# Altium schematic sheet TailLightPrototypeRev3LEDs.SchDoc(TailLightPrototypeRev3LEDs)
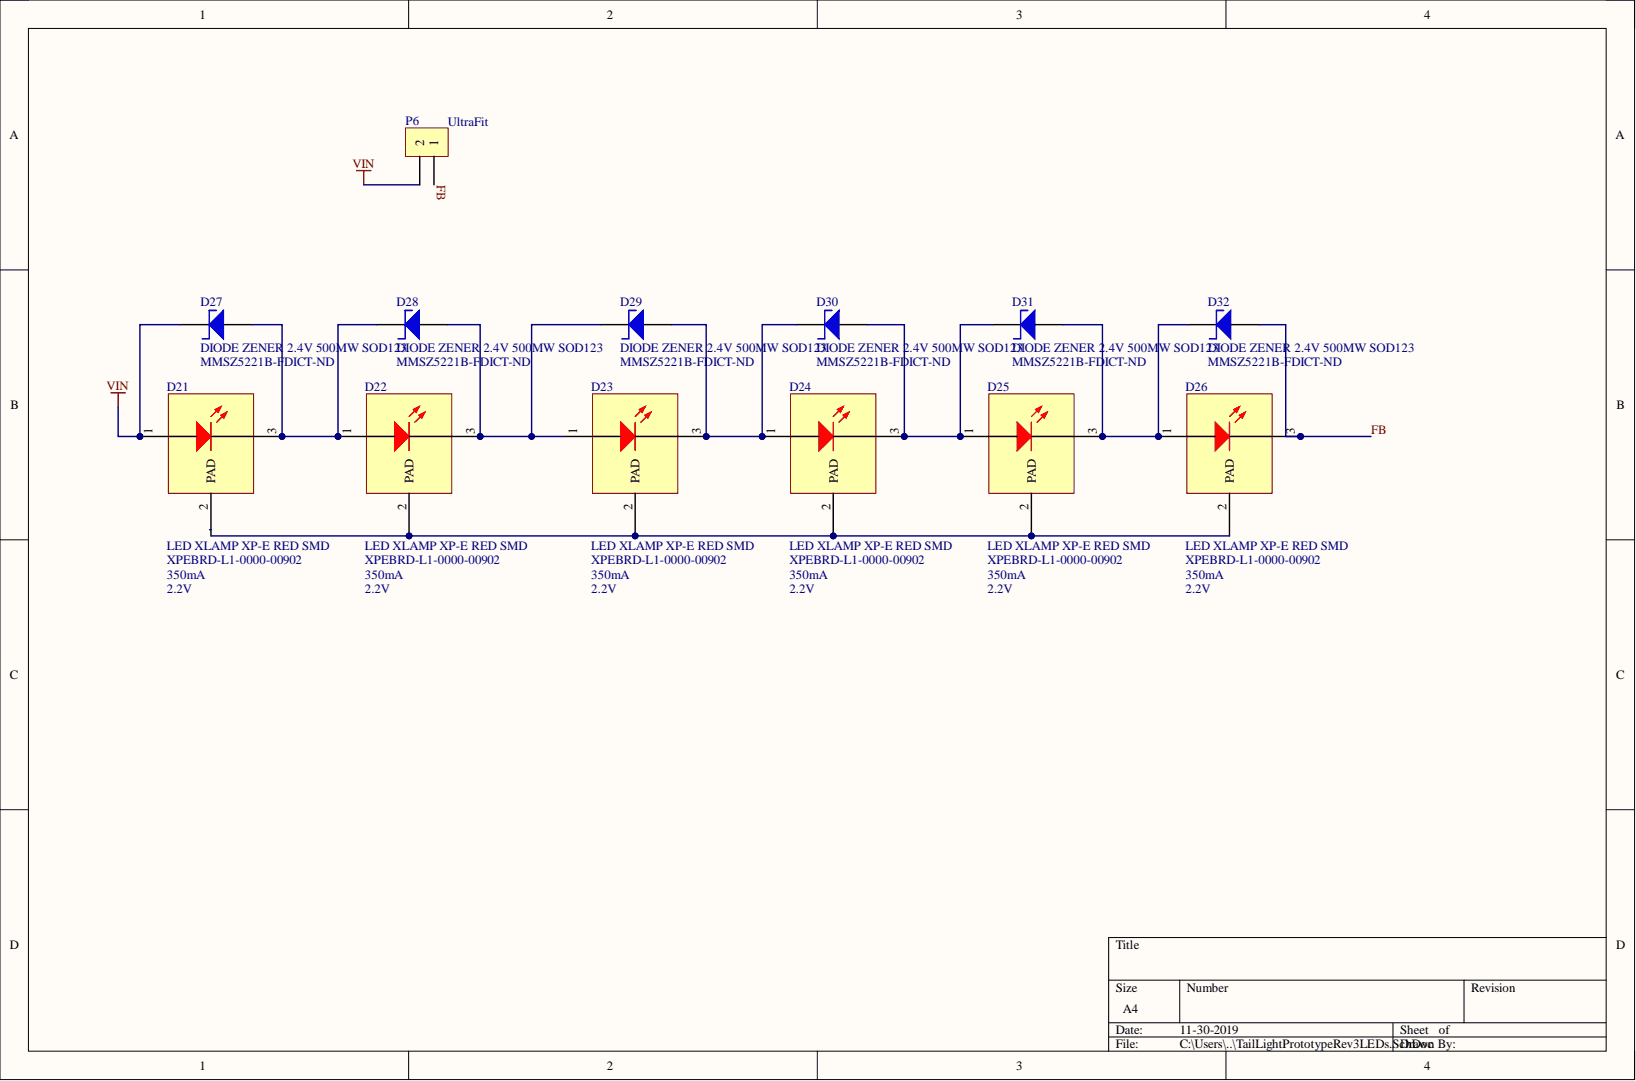
[diagram p0: TailLightPrototypeRev3LEDs.SchDoc]

## Parts
D21  LED XLAMP XP-E RED SMD  Manufacturer Part Number: XPEBRD-L1-0000-00902  [LED CREE XP-E2 1414 2-SMD]
D22  LED XLAMP XP-E RED SMD  Manufacturer Part Number: XPEBRD-L1-0000-00902  [LED CREE XP-E2 1414 2-SMD]
D23  LED XLAMP XP-E RED SMD  Manufacturer Part Number: XPEBRD-L1-0000-00902  [LED CREE XP-E2 1414 2-SMD]
D24  LED XLAMP XP-E RED SMD  Manufacturer Part Number: XPEBRD-L1-0000-00902  [LED CREE XP-E2 1414 2-SMD]
D25  LED XLAMP XP-E RED SMD  Manufacturer Part Number: XPEBRD-L1-0000-00902  [LED CREE XP-E2 1414 2-SMD]
D26  LED XLAMP XP-E RED SMD  Manufacturer Part Number: XPEBRD-L1-0000-00902  [LED CREE XP-E2 1414 2-SMD]
D27  DIODE ZENER 2.4V 500MW SOD123  Supplier Part Number 1: MMSZ5221B-FDICT-ND  [DIODE SOD123]
D28  DIODE ZENER 2.4V 500MW SOD123  Supplier Part Number 1: MMSZ5221B-FDICT-ND  [DIODE SOD123]
D29  DIODE ZENER 2.4V 500MW SOD123  Supplier Part Number 1: MMSZ5221B-FDICT-ND  [DIODE SOD123]
D30  DIODE ZENER 2.4V 500MW SOD123  Supplier Part Number 1: MMSZ5221B-FDICT-ND  [DIODE SOD123]
D31  DIODE ZENER 2.4V 500MW SOD123  Supplier Part Number 1: MMSZ5221B-FDICT-ND  [DIODE SOD123]
D32  DIODE ZENER 2.4V 500MW SOD123  Supplier Part Number 1: MMSZ5221B-FDICT-ND  [DIODE SOD123]
P6  Series: UltraFit  [CONN, 2POS ULTRA-FIT]  (hidden comment: CONN 2POS ULTRA-FIT 0.138")

## Nets
VIN: D21.1 D27.1 P6.2
FB: D26.3 D32.2 P6.1
NetD21_2: D21.2 D22.2 D23.2 D24.2 D25.2 D26.2
NetD21_3: D21.3 D22.1 D27.2 D28.1
NetD22_3: D22.3 D23.1 D28.2 D29.1
NetD23_3: D23.3 D24.1 D29.2 D30.1
NetD24_3: D24.3 D25.1 D30.2 D31.1
NetD25_3: D25.3 D26.1 D31.2 D32.1

## Library data of the parts
D21-D26 = LED XLAMP XP-E RED SMD: LED Lighting Color XLamp® XP-E2 Red 623nm (620nm ~ 625nm) 1414 (3535 Metric); Manufacturer: Cree; Forward Voltage: 2.2V; Max Current Rating: 1A; Test Current: 350mA; Test Temperature: 25°C; Thermal Resistance of Package: 5°C/W; Viewing Angle: 130°; Case/Package: SMD/SMT; Color: Red; Component Kind: Standard; Lead Free: Lead Free; Mount: Surface Mount; Packaging: Tape and Reel; Pricing 1: 1 = 2.00000, 10 = 1.90000, 100 = 1.69980, 250 = 1.54584, 500 = 1.44786 (USD); RoHS: Yes; Stock 1: 1640; Supplier 1: Digi-Key; Supplier Part Number 1: XPEBRD-L1-0000-00902CT-ND; Wavelength: 625nm; Library Name: Schematic Diagrams.SchLib
D27-D32 = DIODE ZENER 2.4V 500MW SOD123: Zener Diode 2.4V 500mW ±5% Surface Mount SOD-123; Manufacturer 1: Diodes; Manufacturer Part Number 1: MMSZ5221B-7-F; Element Configuration: Single; Forward Voltage: 900mV; Impedance: 30R; Max Reverse Leakage Current: 100uA; Test Current: 20mA; Case/Package: SOD-123-2; Component Kind: Standard; ComponentLink1Description: https://datasheet.ciiva.com/34302/1541079-34302404.pdf?src-supplier=Jameco; ComponentLink1URL: https://datasheet.ciiva.com/34302/1541079-34302404.pdf?src-supplier=Jameco; ComponentLink2Description: https://datasheet.ciiva.com/15142/getdatasheetpartid-61111-15142284.pdf?src-supplier=Verical; ComponentLink2URL: https://datasheet.ciiva.com/15142/getdatasheetpartid-61111-15142284.pdf?src-supplier=Verical; ComponentLink3Description: https://datasheet.ciiva.com/4734/ds18010-38133-4734214.pdf?src-supplier=Mouser; ComponentLink3URL: https://datasheet.ciiva.com/4734/ds18010-38133-4734214.pdf?src-supplier=Mouser; ComponentLink4Description: https://datasheet.ciiva.com/890/ds18010-890793.pdf?src-supplier=Digi-Key; ComponentLink4URL: https://datasheet.ciiva.com/890/ds18010-890793.pdf?src-supplier=Digi-Key; ComponentLink5Description: https://datasheet.ciiva.com/890/ds18010-890794.pdf?src-supplier=Digi-Key; ComponentLink5URL: https://datasheet.ciiva.com/890/ds18010-890794.pdf?src-supplier=Digi-Key; Height: 1.35mm; Lead Free: Lead Free; Length: 3.85mm; Max Operating Temperature: 150°C; Max Power Dissipation: 500mW; Min Operating Temperature: -65°C; Mount: Surface Mount; Number of Pins: 2; Package Quantity: 3000; Packaging: Tape and Reel; Peak Reverse Current: 100uA; Power Dissipation: 500mW; Power Rating: 500mW; Pricing 1: 1=0.19000, 10=0.17800, 100=0.07440, 500=0.05840, 1000=0.04056 (USD); Radiation Hardening: No; RoHS: Yes; Stock 1: 37190; Supplier 1: Digi-Key; Termination: SMD/SMT; Tolerance: 5%; Voltage Rating (DC): 2.4V; Voltage Tolerance: 5%; Weight: 0.000353oz; Width: 1.7mm; Working Voltage: 2.4V; Zener Current: 100uA; Zener Voltage: 2.4V; Library Name: Schematic Diagrams.SchLib
P6 = CONN 2POS ULTRA-FIT 0.138": 2 Positions Header, Shrouded Connector 0.138" (3.50mm) Through Hole Gold; Manufacturer 1: Molex, LLC; Manufacturer Part Number 1: 1722861302; Contact Length - Post: 0.126" (3.20mm); Mated Stacking Heights: 26.99mm; Material Flammability Rating: UL94 V-0; Number of Positions: 2; Number of Positions Loaded: All; Shrouding: Shrouded - 4 Wall; Style: Board to Board or Cable; Voltage: 400V; Component Kind: Standard; ComponentLink1Description: http://www.molex.com/pdm_docs/sd/1722861302_sd.pdf; ComponentLink1URL: http://www.molex.com/pdm_docs/sd/1722861302_sd.pdf; Connector Type: Header; Contact Finish - Mating: Gold; Contact Finish - Post: Tin; Contact Finish Thickness - Mating: 30µin (0.76µm); Contact Finish Thickness - Post: 60µin (1.52µm); Contact Length - Mating: -; Contact Material: Copper Alloy; Contact Shape: Square; Contact Type: Male Pin; Current Rating: -; Fastening Type: Latch Holder; Ingress Protection: -; Insulation Color: Black; Insulation Height: 0.465" (11.80mm); Insulation Material: Liquid Crystal Polymer (LCP); Mounting Type: Through Hole; Number of Rows: 1; Operating Temperature: -40°C ~ 120°C; Overall Contact Length: -; Packaging: Tray; Part Status: Active; Pitch - Mating: 0.138" (3.50mm); Row Spacing - Mating: -; Supplier 1: Digi-Key; Supplier Part Number 1: WM11673-ND; Termination: Kinked Pin, Solder; Library Name: Schematic Diagrams.SchLib
